# Altium schematic sheet SM1.SchDoc(SM1)
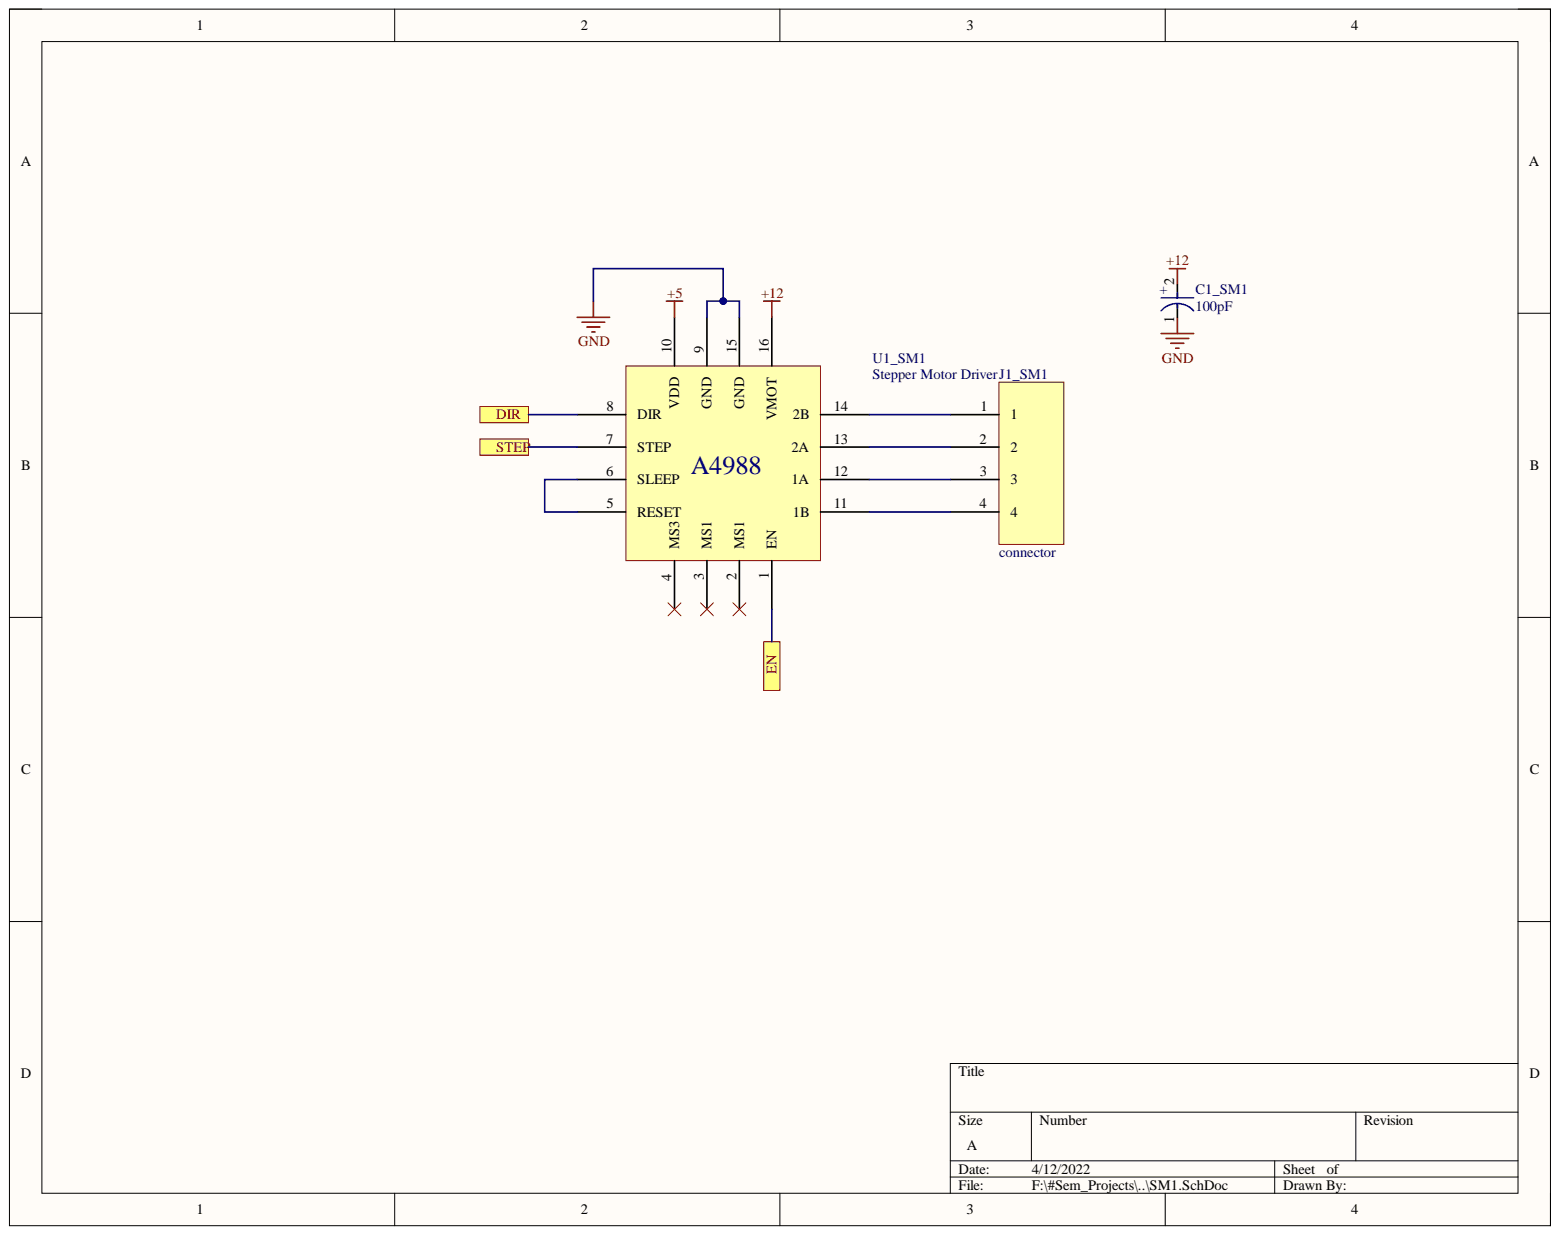
[diagram p0: SM1.SchDoc]

## Parts
C1_SM1  100pF  [FP-SC-MFG]
J1_SM1  connector  [JST_4P]
U1_SM1  Stepper Motor Driver  [A4988]

## Ports
DIR_SM1, EN_SM1, STEP_SM1

## Nets
+5: U1_SM1.10(VDD)
+12: C1_SM1.2 U1_SM1.16(VMOT)
GND: C1_SM1.1 U1_SM1.9(GND) U1_SM1.15(GND)
NetJ1_SM1_1: J1_SM1.1 U1_SM1.14(2B)
NetJ1_SM1_2: J1_SM1.2 U1_SM1.13(2A)
NetJ1_SM1_3: J1_SM1.3 U1_SM1.12(1A)
NetJ1_SM1_4: J1_SM1.4 U1_SM1.11(1B)
NetU1_SM1_1: U1_SM1.1(EN) ; port EN_SM1
NetU1_SM1_2: U1_SM1.2(MS1)
NetU1_SM1_3: U1_SM1.3(MS1)
NetU1_SM1_4: U1_SM1.4(MS3)
NetU1_SM1_5: U1_SM1.5(RESET) U1_SM1.6(SLEEP)
NetU1_SM1_7: U1_SM1.7(STEP) ; port STEP_SM1
NetU1_SM1_8: U1_SM1.8(DIR) ; port DIR_SM1

## Library data of the parts
C1_SM1 = CMP-05427-000087-1: CAP ALUM 10UF 20% 35V SMD; Lead Length: 2.2mm; Leakage Current: 3uA; Life (Hours): 2000Hour; Ripple Current: 30mA; Capacitance: 10uF; Case/Package: Radial; Component Kind: Standard; Construction: Cylindrical; Contact Plating: Tin; Diameter: 5mm; Height: 5.4mm; Height - Seated (Max): 0.213inch; Lead Pitch: 1.5mm; Length: 5.5mm; Library Name: Altium Content Vault; Max Operating Temperature: 85°C; Min Operating Temperature: -40°C; Mount: Surface Mount; Number of Pins: 2; Package Quantity: 1; Packaging: Tape and Reel; Radiation Hardening: No; REACH SVHC: No SVHC; Ripple Current (AC): 30mA; RoHS Compliant: Yes; Series: S; Termination: SMD/SMT; Tolerance: 20%; Voltage: 35V; Voltage Rating: 35V; Voltage Rating (DC): 35V; Width: 5.3mm
J1_SM1 = JST_4P: Component Kind: Standard; Library Name: Schlib.SchLib
U1_SM1 = A4988: Component Kind: Standard; Library Name: Schlib.SchLib
